# Altium schematic sheet 03_MCU1.SchDoc
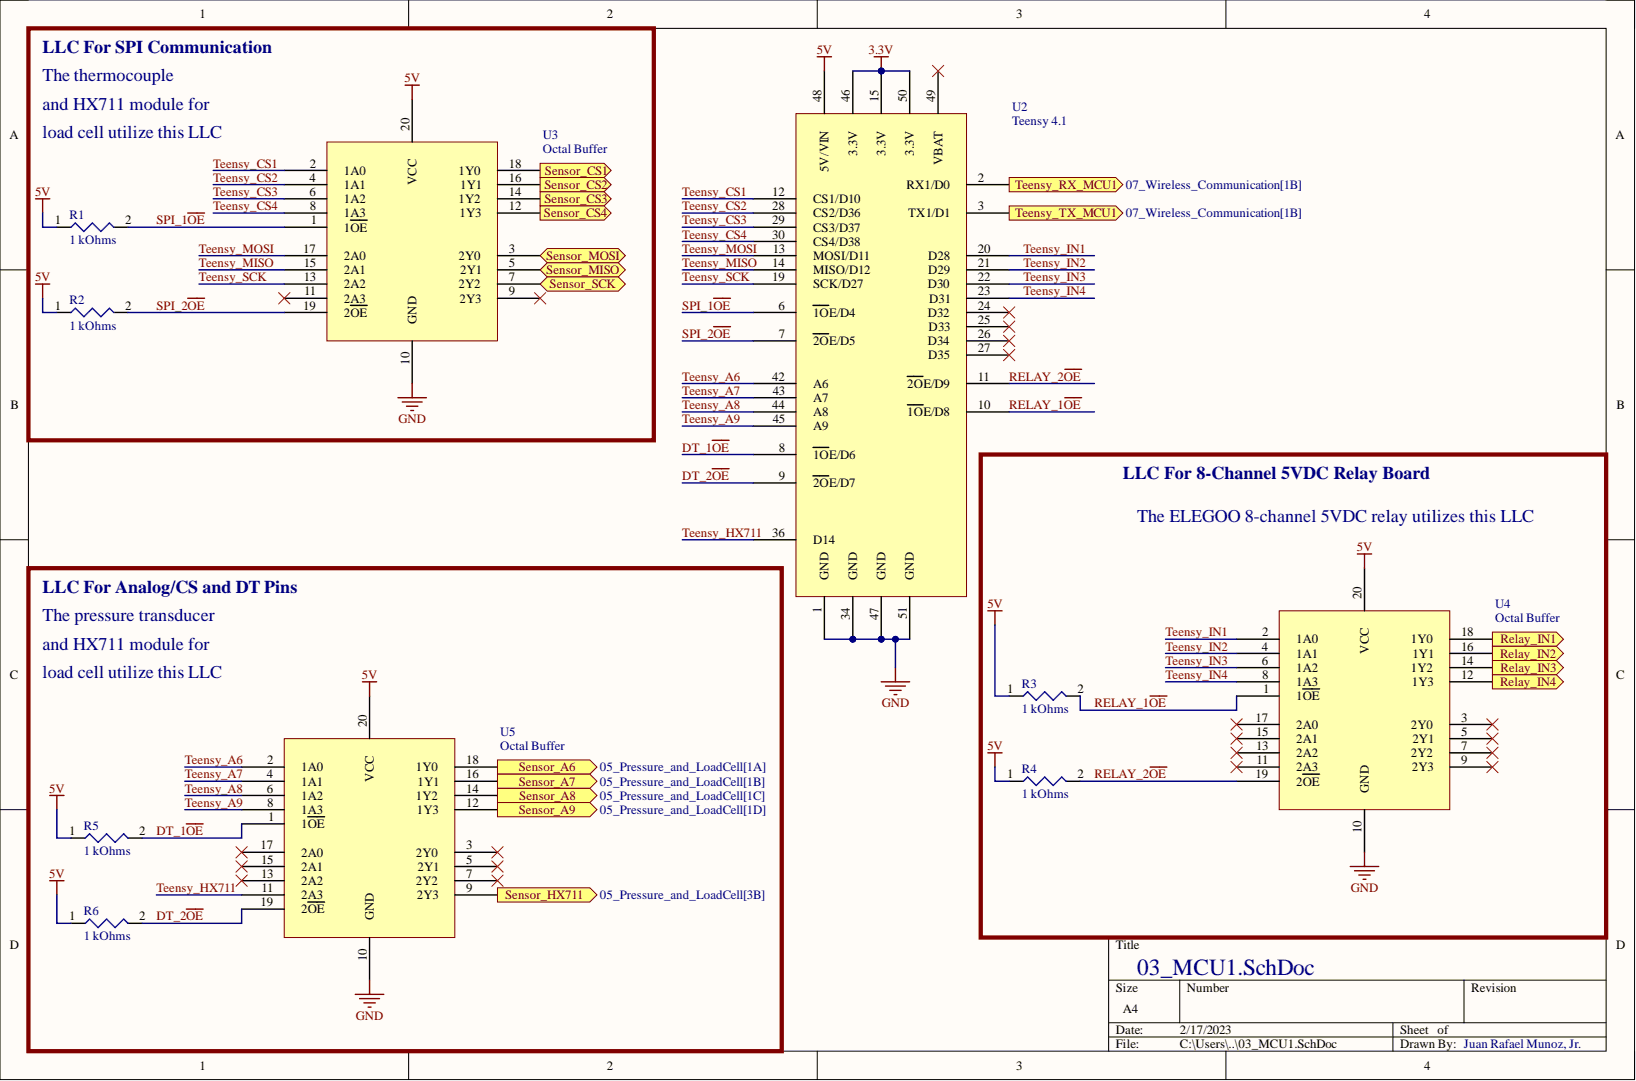
[diagram p0: 03_MCU1.SchDoc]

## Parts
R1  1 kOhms  [1 kOhm Yageo SMD]
R2  1 kOhms  [1 kOhm Yageo SMD]
R3  1 kOhms  [1 kOhm Yageo SMD]
R4  1 kOhms  [1 kOhm Yageo SMD]
R5  1 kOhms  [1 kOhm Yageo SMD]
R6  1 kOhms  [1 kOhm Yageo SMD]
U2  Teensy 4.1  [Teensy 4.1]
U3  Octal Buffer  [74AHC244PW]
U4  Octal Buffer  [74AHC244PW]
U5  Octal Buffer  [74AHC244PW]

## Ports
Relay_IN1, Relay_IN2, Relay_IN3, Relay_IN4, Sensor_A6, Sensor_A7, Sensor_A8, Sensor_A9, Sensor_CS1, Sensor_CS2, Sensor_CS3, Sensor_CS4, Sensor_HX711, Sensor_MISO, Sensor_MOSI, Sensor_SCK, Teensy_RX_MCU1, Teensy_TX_MCU1

## Nets
3.3V: U2.15(3.3V) U2.46(3.3V) U2.50(3.3V)
5V: R1.1 R2.1 R3.1 R4.1 R5.1 R6.1 U2.48(5V/VIN) U3.20(VCC) U4.20(VCC) U5.20(VCC)
GND: U2.1(GND) U2.34(GND) U2.47(GND) U2.51(GND) U3.10(GND) U4.10(GND) U5.10(GND)
DT_1~{OE}: R5.2 U2.8(1OE/D6) U5.1(1OE)
DT_2~{OE}: R6.2 U2.9(2OE/D7) U5.19(2OE)
RELAY_1~{OE}: R3.2 U2.10(1OE/D8) U4.1(1OE)
RELAY_2~{OE}: R4.2 U2.11(2OE/D9) U4.19(2OE)
Relay_IN1: U4.18(1Y0) ; port Relay_IN1
Relay_IN2: U4.16(1Y1) ; port Relay_IN2
Relay_IN3: U4.14(1Y2) ; port Relay_IN3
Relay_IN4: U4.12(1Y3) ; port Relay_IN4
Sensor_A6: U5.18(1Y0) ; port Sensor_A6
Sensor_A7: U5.16(1Y1) ; port Sensor_A7
Sensor_A8: U5.14(1Y2) ; port Sensor_A8
Sensor_A9: U5.12(1Y3) ; port Sensor_A9
Sensor_CS1: U3.18(1Y0) ; port Sensor_CS1
Sensor_CS2: U3.16(1Y1) ; port Sensor_CS2
Sensor_CS3: U3.14(1Y2) ; port Sensor_CS3
Sensor_CS4: U3.12(1Y3) ; port Sensor_CS4
Sensor_HX711: U5.9(2Y3) ; port Sensor_HX711
Sensor_MISO: U3.5(2Y1) ; port Sensor_MISO
Sensor_MOSI: U3.3(2Y0) ; port Sensor_MOSI
Sensor_SCK: U3.7(2Y2) ; port Sensor_SCK
SPI_1~{OE}: R1.2 U2.6(1OE/D4) U3.1(1OE)
SPI_2~{OE}: R2.2 U2.7(2OE/D5) U3.19(2OE)
Teensy_A6: U2.42(A6) U5.2(1A0)
Teensy_A7: U2.43(A7) U5.4(1A1)
Teensy_A8: U2.44(A8) U5.6(1A2)
Teensy_A9: U2.45(A9) U5.8(1A3)
Teensy_CS1: U2.12(CS1/D10) U3.2(1A0)
Teensy_CS2: U2.28(CS2/D36) U3.4(1A1)
Teensy_CS3: U2.29(CS3/D37) U3.6(1A2)
Teensy_CS4: U2.30(CS4/D38) U3.8(1A3)
Teensy_HX711: U2.36(D14) U5.11(2A3)
Teensy_IN1: U2.20(D28) U4.2(1A0)
Teensy_IN2: U2.21(D29) U4.4(1A1)
Teensy_IN3: U2.22(D30) U4.6(1A2)
Teensy_IN4: U2.23(D31) U4.8(1A3)
Teensy_MISO: U2.14(MISO/D12) U3.15(2A1)
Teensy_MOSI: U2.13(MOSI/D11) U3.17(2A0)
Teensy_RX_MCU1: U2.2(RX1/D0) ; port Teensy_RX_MCU1
Teensy_SCK: U2.19(SCK/D27) U3.13(2A2)
Teensy_TX_MCU1: U2.3(TX1/D1) ; port Teensy_TX_MCU1

Not connected: U2 24(D32) 25(D33) 26(D34) 27(D35) 49(VBAT); U3 9(2Y3) 11(2A3); U4 3(2Y0) 5(2Y1) 7(2Y2) 9(2Y3) 11(2A3) 13(2A2) 15(2A1) 17(2A0); U5 3(2Y0) 5(2Y1) 7(2Y2) 13(2A2) 15(2A1) 17(2A0)

## Library data of the parts
R1-R6 = 1 kOhm Yageo SMD: 1 kOhms ±1% 1W Chip Resistor 2512 (6432 Metric) Moisture Resistant Thick Film; Manufacturer: Yageo; Manufacturer Part Number: RC2512FK-071KL; Temperature Coefficient: 100ppm/°C; Value: 1kR; Voltage Rating: 200V; Case Code (Imperial): 2512; Case Code (Metric): 6432; Case/Package: 2512; Category: Chip SMD Resistors; Component Kind: Standard; ComponentLink1Description: https://datasheet.ciiva.com/7671/638316-7671963.pdf?src-supplier=Element14; ComponentLink1URL: https://datasheet.ciiva.com/7671/638316-7671963.pdf?src-supplier=Element14; ComponentLink2Description: https://datasheet.ciiva.com/7671/638316-7671962.pdf?src-supplier=Element14; ComponentLink2URL: https://datasheet.ciiva.com/7671/638316-7671962.pdf?src-supplier=Element14; ComponentLink3Description: https://datasheet.ciiva.com/12555/pyu-rc2512-51-rohs-l-4-12555290.pdf?src-supplier=Mouser; ComponentLink3URL: https://datasheet.ciiva.com/12555/pyu-rc2512-51-rohs-l-4-12555290.pdf?src-supplier=Mouser; ComponentLink4Description: https://datasheet.ciiva.com/8896/pyu-rc2512-51-rohs-l-4-8896186.pdf?src-supplier=Avnet; ComponentLink4URL: https://datasheet.ciiva.com/8896/pyu-rc2512-51-rohs-l-4-8896186.pdf?src-supplier=Avnet; ComponentLink5Description: https://datasheet.ciiva.com/17421/pyu-rc2512-51-rohs-l-4-17421912.pdf?src-supplier=Future+Electronics; ComponentLink5URL: https://datasheet.ciiva.com/17421/pyu-rc2512-51-rohs-l-4-17421912.pdf?src-supplier=Future+Electronics; Construction: Rectangular; Contact Plating: Tin,Matte; Depth: 3.1mm; Features: Moisture Resistant; Height: 0.026inch; Lead Free: Lead Free; Length: 0.25inch; Max Operating Temperature: 155°C; Max Power Dissipation: 1W; Military Standard: Not; Min Operating Temperature: -55°C; Number of Terminations: 2; Packaging: Tape and Reel; Power Rating: 1W; Pricing 1: 4000=0.05361, 8000=0.04850, 12000=0.04629, 28000=0.04435, 100000=0.04255 (USD); Radiation Hardening: No; Resistance Tolerance: 1%; Resistor Type: Thick Film; RoHS: Yes; RoHS Compliant: Yes; Series: RC; Stock 1: 4000; Supplier 1: Digi-Key; Supplier Part Number 1: YAG3390TR-ND; Termination: SMD/SMT; Tolerance: 1%; Width: 3.1mm; Library Name: Ground Support_Schlib.SchLib
U2 = Teensy 4.1: RT1062 Teensy 4.1 - ARM® Cortex®-M7 MCU 32-Bit Embedded Evaluation Board 600 MHz 100 mA RTC Built-in microSD Card reader Arduino IDE compatible; Manufacturer: SparkFun; Manufacturer Part Number: DEV-16996; Category: Embedded Processors and Controllers; Component Kind: Standard; Pricing 1: 1=31.88000 (USD); RoHS: No; Stock 1: 300; Supplier 1: Digi-Key; Supplier Part Number 1: 1568-DEV-16996-ND; Library Name: Ground Support_Schlib.SchLib
U3-U5 = 74AHC244PW: Buffer, Non-Inverting 2 Element 4 Bit per Element 3-State Output 20-TSSOP 8mA 2V-5.5V; Manufacturer: Nexperia; Manufacturer Part Number: 74AHC244PW,118; Category: Logic Gates; Component Kind: Standard; ComponentLink1Description: http://www.farnell.com/datasheets/2342139.pdf?src-supplier=Farnell; ComponentLink1URL: http://www.farnell.com/datasheets/2342139.pdf?src-supplier=Farnell; ComponentLink2Description: https://assets.nexperia.com/documents/data-sheet/74AHC_AHCT244.pdf?src-supplier=Digi-Key; ComponentLink2URL: https://assets.nexperia.com/documents/data-sheet/74AHC_AHCT244.pdf?src-supplier=Digi-Key; Pricing 1: 2500=0.20225, 5000=0.18920, 12500=0.18465 (USD); RoHS: Yes; RoHS Compliant: Yes; Stock 1: 47500; Supplier 1: Digi-Key; Supplier Part Number 1: 1727-5921-2-ND; Library Name: Ground Support_Schlib.SchLib
